# Altium schematic sheet Sensor_Box_PoE.SchDoc
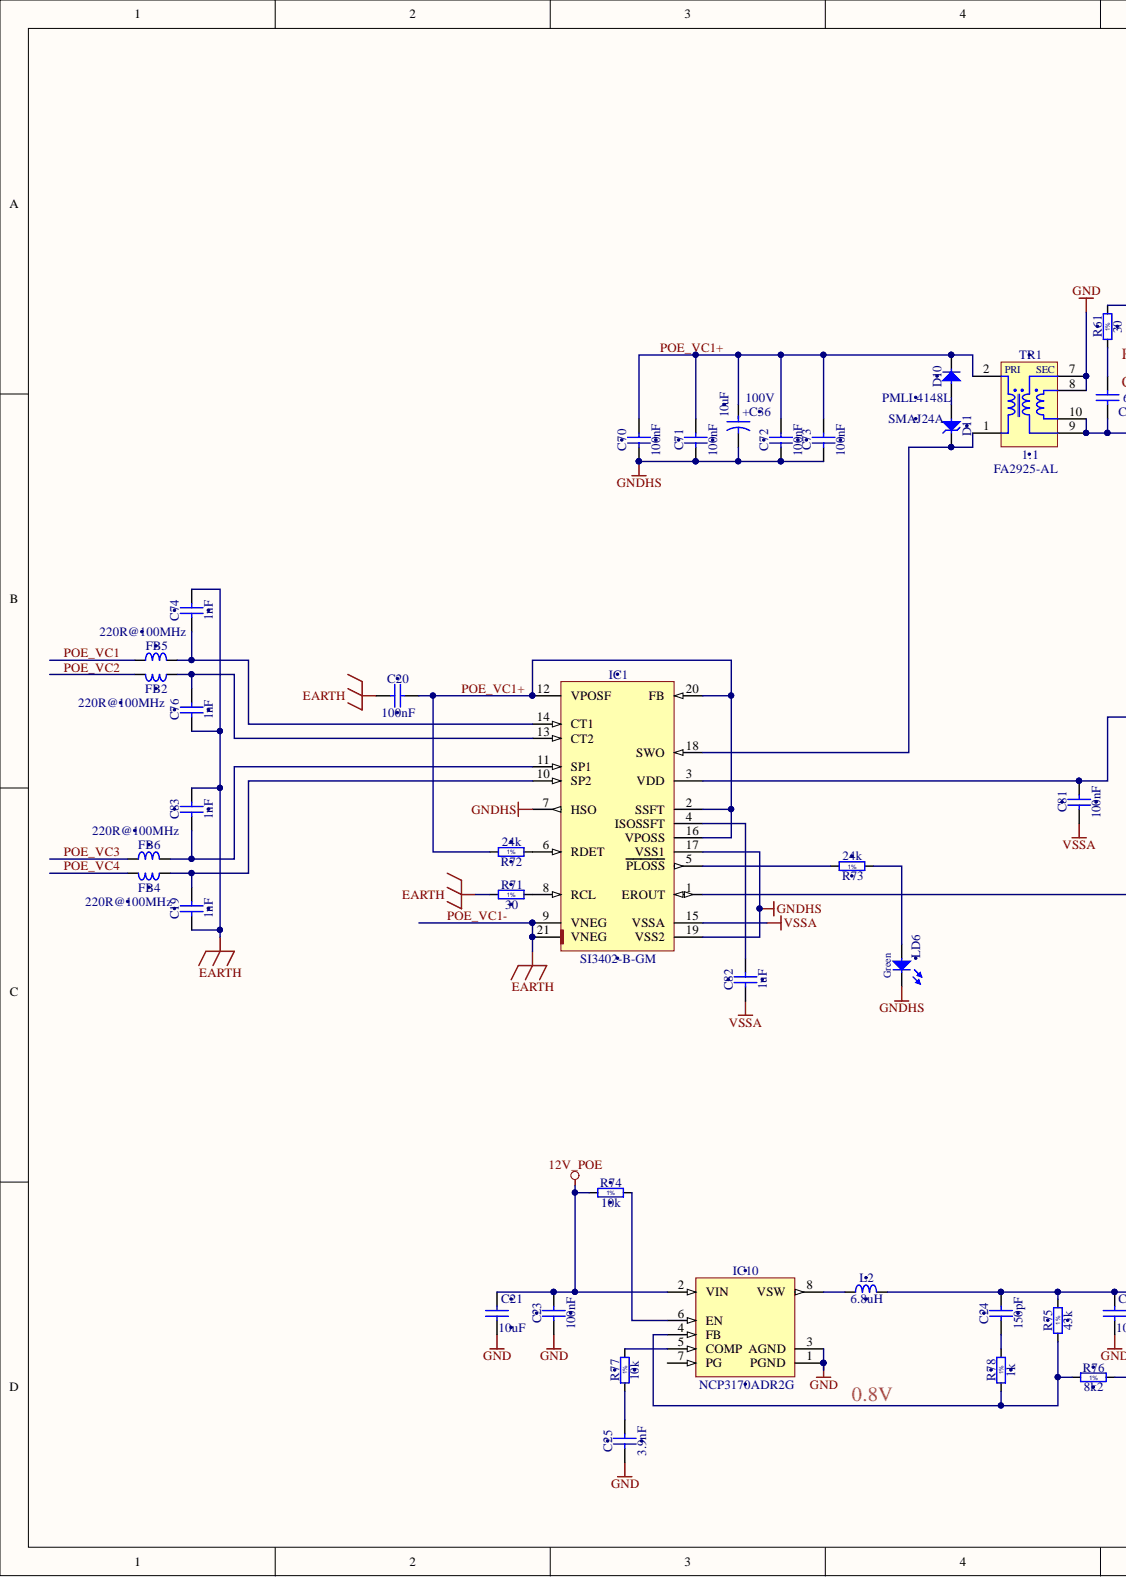
[diagram p0: Sensor_Box_PoE.SchDoc, left]
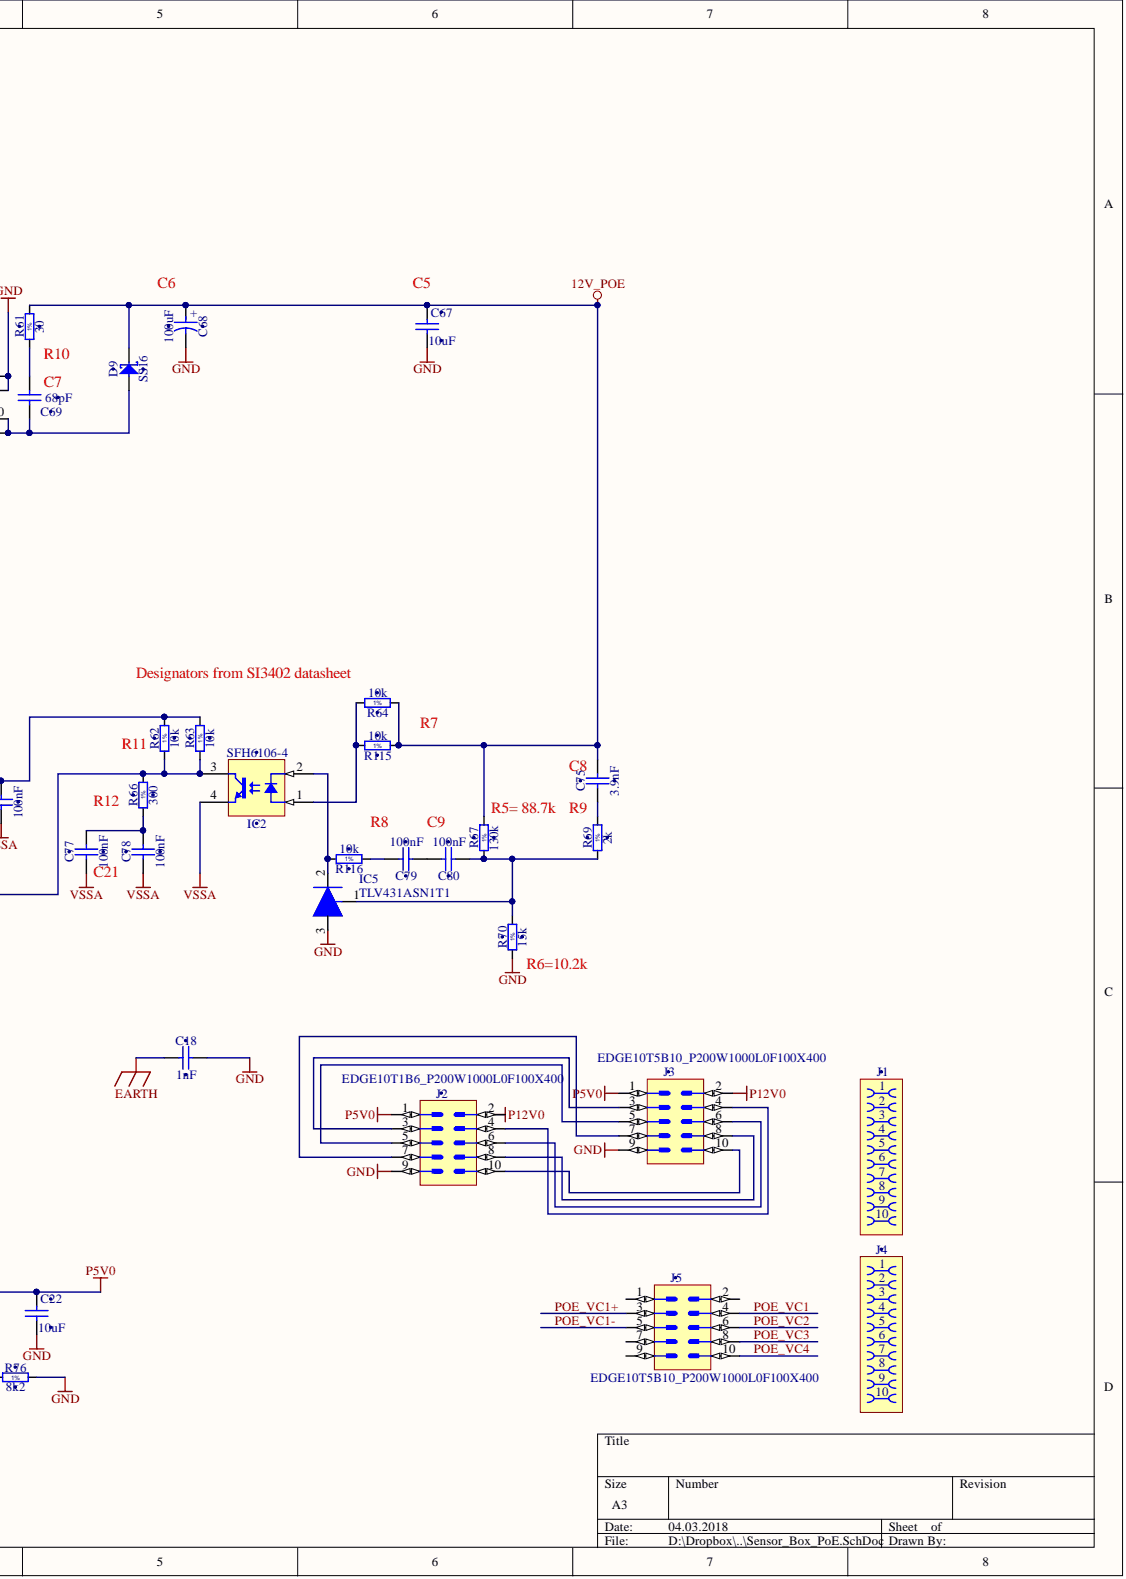
[diagram p1: Sensor_Box_PoE.SchDoc, right]

## Parts
C18  1nF  [CAPC3216X180N]
C19  1nF  [CAPC1608X87N]
C20  100nF  [CAPC3216X140N]
C21  10uF  [CAPC2012X135N]
C22  10uF  [CAPC2012X135N]
C23  100nF  [CAPC1005X55N]
C24  150pF  [CAPC1608X87N]
C25  3.9nF  [CAPC1608X87N]
C36  10uF  Voltage: 100V  [CAPAE830X1020N]
C67  10uF  [CAPC2012X135N]
C68  100uF  [CAPAE660X540N]
C69  68pF  [CAPC1608X87N]
C70  100nF  [CAPC3216X140N]
C71  100nF  [CAPC3216X140N]
C72  100nF  [CAPC3216X140N]
C73  100nF  [CAPC3216X140N]
C74  1nF  [CAPC1608X87N]
C75  3.9nF  [CAPC1608X87N]
C76  1nF  [CAPC1608X87N]
C77  100nF  [CAPC1005X55N]
C78  100nF  [CAPC1005X55N]
C79  100nF  [CAPC1005X55N]
C80  100nF  [CAPC1005X55N]
C81  100nF  [CAPC1005X55N]
C82  1uF  [CAPC1608X87N]
C83  1nF  [CAPC1608X87N]
D9  SS16  [DIOM5127X229N]
D10  PMLL4148L  [DIOMELF3515N]
D11  SMAJ24A  [DIOM5226X262N]
FB2  220R@100MHz  [INDC2012X105N]
FB4  220R@100MHz  [INDC2012X105N]
FB5  220R@100MHz  [INDC2012X105N]
FB6  220R@100MHz  [INDC2012X105N]
IC1  SI3402-B-GM  [QFN80P500X500X80-21N-S280]
IC2  SFH6106-4  [SOIC254P977X406-4N]
IC5  TLV431ASN1T1  [SOT-23]
IC10  NCP3170ADR2G  [SOIC127P600X175-8N]
J1  Family  [AVX_00 9159 010 061 916]  (value not drawn)
J2  Family  [EDGE10T1B6_P200W1000L0F100X400]  (value not drawn)  (no BOM)
J3  Family  [EDGE10T5B10_P200W1000L0F100X400]  (value not drawn)  (no BOM)
J4  Family  [AVX_00 9159 010 061 916]  (value not drawn)
J5  Family  [EDGE10T5B10_P200W1000L0F100X400]  (value not drawn)  (no BOM)
L2  6.8uH  [IND_BOURNS_SRU8043]
LD6  Green  [LED_KINGBRIGHT_KPTR3216]
R61  30  [RESC1608X55N]
R62  10k  [RESC1005X40N]
R63  10k  [RESC1005X40N]
R64  10k  [RESC1005X40N]
R66  300  [RESC1005X40N]
R67  130k  [RESC1608X55N]
R69  2k  [RESC1005X40N]
R70  15k  [RESC1608X55N]
R71  30  [RESC1608X55N]
R72  24k  [RESC1608X55N]
R73  24k  [RESC1608X55N]
R74  10k  [RESC1005X40N]
R75  43k  [RESC1608X55N]
R76  8k2  [RESC1608X55N]
R77  10k  [RESC1005X40N]
R78  1k  [RESC1005X40N]
R115  10k  [RESC1005X40N]
R116  10k  [RESC1005X40N]
TR1  1:1  Manufacturer Part Number: FA2925-AL  [XFMR_COILCRAFT_FA2925-AL]

## Nets
12V_POE: C21.1 C23.2 C67.1 C68.1 C75.2 D9.1 IC10.2(VIN) R61.2 R64.1 R67.2 R74.1 R115.1
GND: C18.2 C21.2 C22.2 C23.1 C25.1 C67.2 C68.2 IC5.3 IC10.1(PGND) IC10.3(AGND) J2.9 J3.9 R70.1 R76.2 TR1.7 TR1.8
GNDHS: C36.2 C70.1 C71.1 C72.1 C73.1 IC1.7(HSO) IC1.17(VSS1) IC1.19(VSS2) LD6.1
P5V0: C22.1 C24.2 J2.1 J3.1 L2.2 R75.2
P12V0: J3.2
VSSA: C77.1 C78.1 C81.1 C82.1 IC1.15(VSSA) IC2.4
POE_VC1: FB5.1 J5.4
POE_VC1+: C20.2 C36.1 C70.2 C71.2 C72.2 C73.2 D10.1 IC1.2(SSFT) IC1.12(VPOSF) IC1.16(VPOSS) IC1.20(FB) J5.3 R72.2 TR1.2
POE_VC1-: C18.1 C19.1 C20.1 C74.2 C76.1 C83.2 IC1.9(VNEG) IC1.21(VNEG) J5.5 R71.1
POE_VC2: FB2.2 J5.6
POE_VC3: FB6.1 J5.8
POE_VC4: FB4.2 J5.10
NetC19_2: C19.2 FB4.1 IC1.10(SP2)
NetC24_1: C24.1 R78.2
NetC25_2: C25.2 R77.1
NetC69_1: C69.1 D9.2 TR1.9 TR1.10
NetC69_2: C69.2 R61.1
NetC74_1: C74.1 FB5.2 IC1.14(CT1)
NetC75_1: C75.1 R69.2
NetC76_2: C76.2 FB2.1 IC1.13(CT2)
NetC77_2: C77.2 C78.2 R66.1
NetC79_1: C79.1 C80.2
NetC79_2: C79.2 R116.1
NetC80_1: C80.1 IC5.1 R67.1 R69.1 R70.2
NetC81_2: C81.2 IC1.3(VDD) R62.2 R63.2
NetC82_2: C82.2 IC1.4(ISOSSFT)
NetC83_1: C83.1 FB6.2 IC1.11(SP1)
NetD10_2: D10.2 D11.2
NetD11_1: D11.1 IC1.18(SWO) TR1.1
NetIC1_1: IC1.1(EROUT) IC2.3 R62.1 R63.1 R66.2
NetIC1_5: IC1.5(PLOSS) R73.2
NetIC1_6: IC1.6(RDET) R72.1
NetIC1_8: IC1.8(RCL) R71.2
NetIC2_1: IC2.1 R64.2 R115.2
NetIC2_2: IC2.2 IC5.2 R116.2
NetIC10_4: IC10.4(FB) R75.1 R76.1 R78.1
NetIC10_5: IC10.5(COMP) R77.2
NetIC10_6: IC10.6(EN) R74.2
NetIC10_8: IC10.8(VSW) L2.1
NetJ2_3: J2.3 J3.3
NetJ2_4: J2.4 J3.4
NetJ2_5: J2.5 J3.5
NetJ2_6: J2.6 J3.6
NetJ2_7: J2.7 J3.7
NetJ2_8: J2.8 J3.8
NetJ2_10: J2.10 J3.10
NetLD6_2: LD6.2 R73.1

Not connected: IC10 7(PG); J2 2; J5 1 2 7 9

## Library data of the parts
C18 = CC1206_1NF_2KV_10%_X7R: Non-polarised capacitor; Manufacturer: GENERIC; Manufacturer1 Part Number: 1206GC102KAT2A; Part Description: High Voltage MLC Chip Capacitor; Database Table Name: Capacitors SMD; TC: X7R; Tolerance: ±10%; Voltage: 2kV; Case: 1206; Component Kind: Standard; Component Type: Standard; CreateDate: 19.11.2012; HelpURL: \\cern.ch\dfs\Applications\Altium\Datasheets\CC1206_X7R_AVX_HV-MLC.pdf; LatestRevisionDate: 17.05.2017; Library Name: Capacitors.DbLib; Manufacturer1 ComponentHeight: 1.8mm; Manufacturer1 Example: AVX; Manufacturer Part Number: CC1206_1NF_2KV_10%_X7R; Mounted: Yes; Part Number: CC1206_1NF_2KV_10%_X7R; Pin Count: 2; Sense: No; SMD: Yes; Socket: No; Status: None; Value: 1nF; Author: CERN DEM JLC
C19 C74 C76 C83 = CC0603_1NF_100V_10%_X7R: Non-polarised capacitor; Manufacturer: GENERIC; Manufacturer1 Part Number: C0603C102K1RACTU; Part Description: SMD Multilayer Chip Ceramic Capacitor; Database Table Name: Capacitors SMD; TC: X7R; Tolerance: ±10%; Voltage: 100V; Case: 0603; Component Kind: Standard; Component Type: Standard; CreateDate: 12.12.2013; HelpURL: \\cern.ch\dfs\Applications\Altium\Datasheets\CC0603_X7R_KEMET.pdf; LatestRevisionDate: 12.12.2013; Library Name: Capacitors.DbLib; Manufacturer1 ComponentHeight: 0.87mm; Manufacturer1 Example: KEMET; Manufacturer Part Number: CC0603_1NF_100V_10%_X7R; Mounted: Yes; Part Number: CC0603_1NF_100V_10%_X7R; Pin Count: 2; Sense: No; SMD: Yes; Socket: No; Status: Preferred; Value: 1nF; Author: CERN DEM JLC
C20 C70-C73 = CC1206_100NF_100V_10%_X7R: Non-polarised capacitor; Manufacturer: GENERIC; Manufacturer1 Part Number: 222260115649; Part Description: SMD Multilayer Chip Ceramic Capacitor; Database Table Name: Capacitors SMD; TC: X7R; Tolerance: ±10%; Voltage: 100V; Case: 1206; Component Kind: Standard; Component Type: Standard; CreateDate: 24.08.2009; HelpURL: \\cern.ch\dfs\Applications\Altium\Datasheets\CC1206_X7R_PHYCOMP.pdf; LatestRevisionDate: 24.08.2009; Library Name: Capacitors.DbLib; Manufacturer1 ComponentHeight: 1.25mm; Manufacturer1 Example: PHYCOMP; Manufacturer Part Number: CC1206_100NF_100V_10%_X7R; Mounted: Yes; Part Number: CC1206_100NF_100V_10%_X7R; Pin Count: 2; Sense: No; SMD: Yes; Socket: No; Status: Preferred; Value: 100nF; Author: CERN DEM JLC
C21 C22 C67 = CC0805_10UF_10V_10%_X7R: Non-polarised capacitor; Manufacturer: GENERIC; Manufacturer1 Part Number: GRM21BR71A106KE51L; Part Description: SMD Multilayer Chip Ceramic Capacitor; Database Table Name: Capacitors SMD; TC: X7R; Tolerance: ±10%; Voltage: 10V; Case: 0805; Component Kind: Standard; Component Type: Standard; CreateDate: 2012-04-30; HelpURL: \\cern.ch\dfs\Applications\Altium\Datasheets\CC0805_X7R_MURATA.pdf; LatestRevisionDate: 2012-04-30; Library Name: Capacitors.DbLib; Manufacturer1 ComponentHeight: 1.35mm; Manufacturer1 Example: MURATA; Manufacturer Part Number: CC0805_10UF_10V_10%_X7R; Mounted: Yes; Part Number: CC0805_10UF_10V_10%_X7R; Pin Count: 2; SMD: Yes; Socket: No; Status: Preferred; Table: Capacitors SMD; Value: 10uF; Author: CERN DEM JLC
C23 C77-C81 = CC0402_100NF_16V_10%_X5R: Non-polarised capacitor; Manufacturer: GENERIC; Manufacturer1 Part Number: GRM155R61C104KA88D; Part Description: SMD Multilayer Chip Ceramic Capacitor; Database Table Name: Capacitors SMD; TC: X5R; Tolerance: ±10%; Voltage: 16V; Case: 0402; Component Kind: Standard; Component Type: Standard; CreateDate: 10.12.2013; HelpURL: \\cern.ch\dfs\Applications\Altium\Datasheets\CC0402_X5R_MURATA_GRM.pdf; LatestRevisionDate: 10.12.2013; Library Name: Capacitors.DbLib; Manufacturer1 ComponentHeight: 0.55mm; Manufacturer1 Example: MURATA; Manufacturer Part Number: CC0402_100NF_16V_10%_X5R; Mounted: Yes; Part Number: CC0402_100NF_16V_10%_X5R; Pin Count: 2; Sense: No; SMD: Yes; Socket: No; Status: None; Value: 100nF; Author: CERN DEM JLC
C24 = CC0603_150PF_50V_1%_NP0: Non-polarised capacitor; Manufacturer: GENERIC; Manufacturer1 Part Number: CC0603FRNPO9BN151; Part Description: SMD Multilayer Chip Ceramic Capacitor; Database Table Name: Capacitors SMD; TC: C0G/NP0; Tolerance: ±1%; Voltage: 50V; Case: 0603; Component Kind: Standard; Component Type: Standard; CreateDate: 17.02.2016; HelpURL: \\cern.ch\dfs\Applications\Altium\Datasheets\CC0805_NP0_PHYCOMP_16VTO50V.pdf; LatestRevisionDate: 17.02.2016; Library Name: Capacitors.DbLib; Manufacturer1 ComponentHeight: 0.7mm; Manufacturer1 Example: PHYCOMP; Manufacturer Part Number: CC0603_150PF_50V_1%_NP0; Mounted: Yes; Part Number: CC0603_150PF_50V_1%_NP0; Pin Count: 2; Sense: No; SMD: Yes; Socket: No; Status: None; Value: 150pF; Author: CERN DEM MR
C25 C75 = CC0603_3.9NF_50V_5%_X7R: Non-polarised capacitor; Manufacturer: GENERIC; Manufacturer1 Part Number: 223858615631; Part Description: SMD Multilayer Chip Ceramic Capacitor; Database Table Name: Capacitors SMD; TC: X7R; Tolerance: ±5%; Voltage: 50V; Case: 0603; Component Kind: Standard; Component Type: Standard; CreateDate: 03.12.2007; HelpURL: \\cern.ch\dfs\Applications\Altium\Datasheets\CC0603_X7R_PHYCOMP.pdf; LatestRevisionDate: 03.12.2007; Library Name: Capacitors.DbLib; Manufacturer1 ComponentHeight: 0.87mm; Manufacturer1 Example: PHYCOMP; Manufacturer Part Number: CC0603_3.9NF_50V_5%_X7R; Mounted: Yes; Part Number: CC0603_3.9NF_50V_5%_X7R; Pin Count: 2; Sense: No; SMD: Yes; Socket: No; Status: Preferred; Value: 3.9nF; Author: CERN DEM JLC
C36 = (no symbol name): 10uF, 100V, 1.5R; Manufacturer: PANASONIC; Manufacturer Part Number: EEEHD2A100P; Part Description: Aluminum Electrolytic SMT Capacitor; Part Number: CAE830-1020_10UF_100V_20%; Table: Capacitors SMD; Tolerance: ±20%; Case: F; ComponentHeight: 10.2mm; Component Kind: Standard; Component Type: Standard; CreateDate: 2007-12-03; HelpURL: \\cern.ch\dfs\Applications\Altium\Datasheets\CAE_PANASONIC_FK.pdf; LatestRevisionDate: 2014-11-05; Library Name: LIBRARY_SCH.SCHLIB; Mounted: Yes; Pin Count: 2; SMD: Yes; Socket: No; Status: Recommended; Value: 10uF; Author: CERN DEM JLC
C68 = CAE660-540_100UF_16V_20%: Polarised capacitor; Manufacturer: PANASONIC; Manufacturer Part Number: EEEFK1C101AP; Part Description: Aluminum Electrolytic SMT Capacitor; Database Table Name: Capacitors SMD; Tolerance: ±20%; Voltage: 16V; Case: D; ComponentHeight: 5.8mm; Component Kind: Standard; Component Type: Standard; CreateDate: 03.12.2007; HelpURL: \\cern.ch\dfs\Applications\Altium\Datasheets\CAE_PANASONIC_FK.pdf; LatestRevisionDate: 05.11.2014; Library Name: Capacitors.DbLib; Mounted: Yes; Part Number: CAE660-540_100UF_16V_20%; Pin Count: 2; Sense: No; SMD: Yes; Socket: No; Status: Not Recommended; Value: 100uF; Author: CERN DEM JLC
C69 = CC0603_68PF_50V_5%_NP0: Non-polarised capacitor; Manufacturer: GENERIC; Manufacturer1 Part Number: 223886715689; Part Description: SMD Multilayer Chip Ceramic Capacitor; Database Table Name: Capacitors SMD; TC: C0G/NP0; Tolerance: ±5%; Voltage: 50V; Case: 0603; Component Kind: Standard; Component Type: Standard; CreateDate: 03.12.2007; HelpURL: \\cern.ch\dfs\Applications\Altium\Datasheets\CC0603_NP0_PHYCOMP.pdf; LatestRevisionDate: 03.12.2007; Library Name: Capacitors.DbLib; Manufacturer1 ComponentHeight: 0.87mm; Manufacturer1 Example: PHYCOMP; Manufacturer Part Number: CC0603_68PF_50V_5%_NP0; Mounted: Yes; Part Number: CC0603_68PF_50V_5%_NP0; Pin Count: 2; Sense: No; SMD: Yes; Socket: No; Status: Preferred; Value: 68pF; Author: CERN DEM JLC
C82 = CC0603_1UF_16V_10%_X7R: Non-polarised capacitor; Manufacturer: GENERIC; Manufacturer1 Part Number: 223878615663; Part Description: SMD Multilayer Chip Ceramic Capacitor; Database Table Name: Capacitors SMD; TC: X7R; Tolerance: ±10%; Voltage: 16V; Case: 0603; Component Kind: Standard; Component Type: Standard; CreateDate: 03.12.2007; HelpURL: \\cern.ch\dfs\Applications\Altium\Datasheets\CC0603_X7R_PHYCOMP.pdf; LatestRevisionDate: 17.04.2015; Library Name: Capacitors.DbLib; Manufacturer1 ComponentHeight: 0.9mm; Manufacturer1 Example: YAGEO PHYCOMP; Manufacturer Part Number: CC0603_1uF_16V_10%_X7R; Mounted: Yes; Part Number: CC0603_1UF_16V_10%_X7R; Pin Count: 2; Sense: No; SMD: Yes; Socket: No; Status: None; Value: 1uF; Author: CERN DEM JLC
D9 = SS16: Schottky Diode; Manufacturer: VISHAY GENERAL SEMICONDUCTOR; Part Description: Surface Mount Schottky Barrier Rectifier; Bonding: No; Database Table Name: Diodes; Power: 1A; PressFit: No; Voltage: 60V; Case: DO-214AC; ComponentHeight: 2.29mm; Component Kind: Standard; Component Type: Standard; CreateDate: 15.07.2008; Device: SS16; HelpURL: \\cern.ch\dfs\Applications\Altium\Datasheets\SS16.pdf; LatestRevisionDate: 23.08.2010; Library Name: ICs And Semiconductors.DbLib; Manufacturer Part Number: SS16; Mounted: Yes; PackageDescription: DO-214-AC Molded Diode, Body 5.1x2.7mm, IPC Medium Density; Part Number: SS16; Pin Count: 2; Sense: No; SMD: Yes; Socket: No; Author: CERN DEM JLC
D10 = PMLL4148L: Diode; Manufacturer: NXP SEMICONDUCTORS; Part Description: High-speed switching diodes; Bonding: No; Database Table Name: Diodes; Power: 200mA; PressFit: No; Voltage: 75V; Case: SOD-80C; ComponentHeight: 1.6mm; Component Kind: Standard; Component Type: Standard; CreateDate: 30.03.2009; Device: PMLL4148L; HelpURL: \\cern.ch\dfs\Applications\Altium\Datasheets\PMLL4148L.pdf; LatestRevisionDate: 09.12.2009; Library Name: ICs And Semiconductors.DbLib; Manufacturer Part Number: PMLL4148L; Mounted: Yes; PackageDescription: SOD80C, MELF Diode, 2-Leads, Body 3.5x1.5mm, IPC Medium Density; Part Number: PMLL4148L; Pin Count: 2; Sense: No; SMD: Yes; Socket: No; Status: Not recommended; Author: CERN DEM JLC
D11 = SMAJ24A: Transient Voltage Suppressor Diode (Uni-Directional); Manufacturer: BOURNS; Part Description: Surface Mount Uni-directional Transient Voltage Suppressor Diode; Bonding: No; Database Table Name: Diodes; Power: 400W; PressFit: No; Voltage: 24V; Case: DO-214AC; ComponentHeight: 2.62mm; Component Kind: Standard; Component Type: Standard; CreateDate: 27.06.2011; Device: SMAJ24A; HelpURL: \\cern.ch\dfs\Applications\Altium\Datasheets\SMAJ24A.pdf; LatestRevisionDate: 27.06.2011; Library Name: ICs And Semiconductors.DbLib; Manufacturer Part Number: SMAJ24A; Mounted: Yes; PackageDescription: DO-214-AC/SMA Diode, Molded Body; 5.21mm L X 2.605mm W X 2.62mm H, IPC Medium Density; Part Number: SMAJ24A; Pin Count: 2; Sense: No; SMD: Yes; Socket: No; Author: CERN DEM JLC
FB2 FB4-FB6 = IND0805_MURATA_BLM21PG221SN1D: Inductor; Manufacturer: MURATA; Part Description: SMD EMIFIL Suppression Chip Ferrite Bead; Database Table Name: Inductors SMD; Power: 2A; Resistance: 0.05R; Tolerance: ±25%; Case: 0805; ComponentHeight: 1.05mm; Component Kind: Standard; Component Type: Standard; CreateDate: 2010-01-25; HelpURL: \\cern.ch\dfs\Applications\Altium\Datasheets\IND0402_MURATA_BLM21P.pdf; LatestRevisionDate: 2010-01-25; Library Name: Inductors & Transformers.DbLib; Manufacturer Part Number: BLM21PG221SN1D; Mounted: Yes; Part Number: IND0805_MURATA_BLM21PG221SN1D; Pin Count: 2; SMD: Yes; Socket: No; Status: None; Table: Inductors SMD; Value: 220R@100MHz; Author: CERN DEM JLC
IC1 = SI3402-B-GM: Fully Integrated IEEE 802.3 Compliant PoE PD Interface and Low-EMI Switching Regulator; Manufacturer: SILICON LABS; Bonding: No; Database Table Name: Regulators; PressFit: No; Case: QFN20; ComponentHeight: 0.8mm; Component Kind: Standard; Component Type: Standard; CreateDate: 21.02.2018; Device: SI3402-B-GM; HelpURL: \\cern.ch\dfs\Applications\Altium\Datasheets\SI3402-B-GM.pdf; LatestRevisionDate: 21.02.2018; Library Name: ICs And Semiconductors.DbLib; Manufacturer Part Number: SI3402-B-GM; Mounted: Yes; PackageDescription: QFN, 0.80mm Pitch, Square 20 Pin, 5.00mm L X 5.00mm W X 0.80mm H body (W/Thermal Tab 2.8mm X 2.8mm), IPC Medium Density; Part Description: Fully Integrated IEEE 802.3 Compliant PoE PD Interface and Low-EMI Switching Regulator; Part Number: SI3402-B-GM; Pin Count: 21; Sense: No; SMD: Yes; Socket: No; Author: CERN DEM MR
IC2 = SFH6106-4: Single Optocoupler; Manufacturer: VISHAY SEMICONDUCTORS; Part Description: Optocoupler, Phototransistor Output, High Reliability, 5300 VRMS; Bonding: No; Database Table Name: Optocouplers; PressFit: No; Case: SOIC4; ComponentHeight: 4.06mm; Component Kind: Standard; Component Type: Standard; CreateDate: 15.02.2018; Device: SFH6106-4; HelpURL: \\cern.ch\dfs\Applications\Altium\Datasheets\SFH6106-4.pdf; LatestRevisionDate: 15.02.2018; Library Name: ICs And Semiconductors.DbLib; Manufacturer Part Number: SFH6106-4; Mounted: Yes; PackageDescription: SOIC, 2.54mm pitch; 4 pin, 6.65mm W X 4.69mm L, IPC Medium Density; Part Number: SFH6106-4; Pin Count: 4; Sense: No; SMD: Yes; Socket: No; Author: CERN DEM MR
IC5 = REF_TLV431_SOT23_16V: 16V 1.24V ADJ Voltage reference; Component Kind: Standard; Library Name: LIBRARY_SCH.SCHLIB; Supplier 1: Newark; Supplier Part Number 1: 31K7719
IC10 = NCP3170ADR2G: Synchronous PWM Switching Converter; Manufacturer: ON SEMICONDUCTOR; Bonding: No; Database Table Name: Analog & Interface; PressFit: No; Case: SOIC8; ComponentHeight: 1.75mm; Component Kind: Standard; Component Type: Standard; CreateDate: 30.05.2016; Device: NCP3170ADR2G; HelpURL: \\cern.ch\dfs\Applications\Altium\Datasheets\NCP3170ADR2G.pdf; LatestRevisionDate: 30.05.2016; Library Name: ICs And Semiconductors.DbLib; Manufacturer Part Number: NCP3170ADR2G; Mounted: Yes; PackageDescription: SOIC 8, Pitch 1.27mm - Body 4x5mm, IPC Medium Density; Part Description: Synchronous PWM Switching Converter; Part Number: NCP3170ADR2G; Pin Count: 8; Sense: No; SMD: Yes; Socket: No; Author: CERN DEM MR
J1 J4 = AVX_00 9159 010 061 916: Open Ended Card Edge Connector, 9159 Series; Manufacturer: AVX; Part Description: 10 Contacts, 2mm Pitch, Open Ended Card Edge Connector, 9159 Series; Database Table Name: Miscellaneous; PressFit: No; ComponentHeight: 1.6mm; Component Kind: Standard; Component Type: Standard; CreateDate: 13.02.2018; HelpURL: \\cern.ch\dfs\Applications\Altium\Datasheets\AVX_00 9159 010 061 916.pdf; LatestRevisionDate: 13.02.2018; Library Name: Connectors.DbLib; Manufacturer Part Number: 00 9159 010 061 916; Mounted: Yes; Part Number: AVX_00 9159 010 061 916; Pin Count: 10; Sense: No; SMD: No; Socket: No; Status: None; Author: CERN DEM MR
J2 = EDGE10T1B6_P200W1000L0F100X400: PCB Edge Connector 10 (2x5); Part Description: 10 Contacts Board Edge Connector, Pitch 2mm, Length 0mm, Width 10mm, Finger Lenght 4mm, Finger Width 1mm; Database Table Name: Miscellaneous; Mounted: No; PressFit: No; ComponentHeight: 0mm; Component Type: Standard (No BOM); CreateDate: 14.02.2018; HelpURL: \\cern.ch\dfs\Applications\Altium\Datasheets\EDGE10T1B6_P200W1000L0F100X400.pdf; LatestRevisionDate: 14.02.2018; Library Name: Connectors.DbLib; Part Number: EDGE10T1B6_P200W1000L0F100X400; Pin Count: 10; Sense: No; SMD: No; Socket: No; Status: None; Author: CERN DEM MR
J3 J5 = EDGE10T5B10_P200W1000L0F100X400: PCB Edge Connector 10 (2x5); Part Description: 10 Contacts Board Edge Connector, Pitch 2mm, Length 0mm, Width 10mm, Finger Lenght 4mm, Finger Width 1mm; Database Table Name: Miscellaneous; Mounted: No; PressFit: No; ComponentHeight: 0mm; Component Type: Standard (No BOM); CreateDate: 14.02.2018; HelpURL: \\cern.ch\dfs\Applications\Altium\Datasheets\EDGE10T5B10_P200W1000L0F100X400.pdf; LatestRevisionDate: 14.02.2018; Library Name: Connectors.DbLib; Part Number: EDGE10T5B10_P200W1000L0F100X400; Pin Count: 10; Sense: No; SMD: No; Socket: No; Status: None; Author: CERN DEM MR
L2 = IND_6.8UH_30%_BOURNS_SRU8043: Inductor; Manufacturer: BOURNS; Manufacturer Part Number: SRU8043-6R8Y; Part Description: Shielded SMD Power Inductor; Database Table Name: Inductors SMD; Power: 3.8A; Resistance: 0.0224R; Tolerance: ±30%; ComponentHeight: 4.6mm; Component Kind: Standard; Component Type: Standard; CreateDate: 06.08.2015; HelpURL: \\cern.ch\dfs\Applications\Altium\Datasheets\IND_BOURNS_SRU8043.pdf; LatestRevisionDate: 06.08.2015; Library Name: Inductors & Transformers.DbLib; Mounted: Yes; Part Number: IND_6.8UH_30%_BOURNS_SRU8043; Pin Count: 2; Sense: No; SMD: Yes; Socket: No; Status: None; Value: 6.8uH; Author: CERN DEM JMW
LD6 = LED_KINGBRIGHT_KPTR-3216CGCK: LED Green 1A 2C; Part Description: Reverse Mount LED 1.4x1.6mm Green; Bonding: No; Database Table Name: LEDs & Displays; PressFit: No; Color: Green; ComponentHeight: 0.35mm; Component Kind: Standard; Component Type: Standard; CreateDate: 15.02.2016; HelpURL: \\cern.ch\dfs\Applications\Altium\Datasheets\LED_KINGBRIGHT_KPTR-3216CGCK.pdf; LatestRevisionDate: 15.02.2016; Library Name: ICs And Semiconductors.DbLib; Manufacturer: KINGBRIGHT; Manufacturer Part Number: KPTR-3216CGCK; Mounted: Yes; PackageDescription: Reverse Mount LED 3216, Hole 2.1x2.3mm 0.35mm Height; Part Number: LED_KINGBRIGHT_KPTR-3216CGCK; Pin Count: 2; Sense: No; SMD: Yes; Socket: No; Author: CERN DEM MR
R61 R71 = R0603_30R_1%_0.1W_100PPM: Resistor - 1%; Manufacturer: GENERIC; Manufacturer1 Part Number: NRC06F30R0TRF; Part Description: General Purpose Thick Film Chip Resistor; Database Table Name: Resistors SMD; Power: 0.1W; PressFit: No; TC: ±100ppm/°C; Case: 0603; Component Kind: Standard; Component Type: Standard; CreateDate: 03.12.2007; HelpURL: \\cern.ch\dfs\Applications\Altium\Datasheets\R0603_NIC_NRC.pdf; LatestRevisionDate: 13.03.2008; Library Name: Resistors.DbLib; Manufacturer1 ComponentHeight: 0.55mm; Manufacturer1 Example: NIC COMPONENT; Manufacturer Part Number: R0603_30R_1%_0.1W_100PPM; Mounted: Yes; Part Number: R0603_30R_1%_0.1W_100PPM; Pin Count: 2; Sense: No; SMD: Yes; Socket: No; Status: Preferred; Tolerance: ±1%; Value: 30; Author: CERN DEM JLC
R62-R64 R74 R77 R115 R116 = R0402_10K_1%_0.0625W_100PPM: Resistor - 1%; Manufacturer: GENERIC; Manufacturer1 Part Number: 232270671003L; Part Description: General Purpose Thick Film Chip Resistor; Database Table Name: Resistors SMD; PressFit: No; TC: ±100ppm/°C; Case: 0402; Component Kind: Standard; Component Type: Standard; CreateDate: 03.12.2007; HelpURL: \\cern.ch\dfs\Applications\Altium\Datasheets\R0402_Phycomp_RC0402.pdf; LatestRevisionDate: 17.10.2012; Library Name: Resistors.DbLib; Manufacturer1 ComponentHeight: 0.4mm; Manufacturer1 Example: YAGEO PHYCOMP; Manufacturer Part Number: R0402_10K_1%_0.0625W_100PPM; Mounted: Yes; Part Number: R0402_10K_1%_0.0625W_100PPM; Pin Count: 2; Power: 0.0625W; Sense: No; SMD: Yes; Socket: No; Status: Not Recommended; Tolerance: ±1%; Value: 10k; Author: CERN DEM JLC
R66 = R0402_300R_1%_0.0625W_100PPM: Resistor - 1%; Manufacturer: GENERIC; Manufacturer1 Part Number: 232270673001L; Part Description: General Purpose Thick Film Chip Resistor; Database Table Name: Resistors SMD; PressFit: No; TC: ±100ppm/°C; Case: 0402; Component Kind: Standard; Component Type: Standard; CreateDate: 03.12.2007; HelpURL: \\cern.ch\dfs\Applications\Altium\Datasheets\R0402_Phycomp_RC0402.pdf; LatestRevisionDate: 17.10.2012; Library Name: Resistors.DbLib; Manufacturer1 ComponentHeight: 0.4mm; Manufacturer1 Example: YAGEO PHYCOMP; Manufacturer Part Number: R0402_300R_1%_0.0625W_100PPM; Mounted: Yes; Part Number: R0402_300R_1%_0.0625W_100PPM; Pin Count: 2; Power: 0.0625W; Sense: No; SMD: Yes; Socket: No; Status: Not Recommended; Tolerance: ±1%; Value: 300; Author: CERN DEM JLC
R67 = R0603_130K_1%_0.1W_100PPM: Resistor - 1%; Manufacturer: GENERIC; Manufacturer1 Part Number: NRC06F1303TRF; Part Description: General Purpose Thick Film Chip Resistor; Database Table Name: Resistors SMD; Power: 0.1W; PressFit: No; TC: ±100ppm/°C; Case: 0603; Component Kind: Standard; Component Type: Standard; CreateDate: 03.12.2007; HelpURL: \\cern.ch\dfs\Applications\Altium\Datasheets\R0603_NIC_NRC.pdf; LatestRevisionDate: 13.03.2008; Library Name: Resistors.DbLib; Manufacturer1 ComponentHeight: 0.55mm; Manufacturer1 Example: NIC COMPONENT; Manufacturer Part Number: R0603_130K_1%_0.1W_100PPM; Mounted: Yes; Part Number: R0603_130K_1%_0.1W_100PPM; Pin Count: 2; Sense: No; SMD: Yes; Socket: No; Status: Preferred; Tolerance: ±1%; Value: 130k; Author: CERN DEM JLC
R69 = R0402_2K_1%_0.0625W_100PPM: Resistor - 1%; Manufacturer: GENERIC; Manufacturer1 Part Number: 232270672002L; Part Description: General Purpose Thick Film Chip Resistor; Database Table Name: Resistors SMD; PressFit: No; TC: ±100ppm/°C; Case: 0402; Component Kind: Standard; Component Type: Standard; CreateDate: 03.12.2007; HelpURL: \\cern.ch\dfs\Applications\Altium\Datasheets\R0402_Phycomp_RC0402.pdf; LatestRevisionDate: 17.10.2012; Library Name: Resistors.DbLib; Manufacturer1 ComponentHeight: 0.4mm; Manufacturer1 Example: YAGEO PHYCOMP; Manufacturer Part Number: R0402_2K_1%_0.0625W_100PPM; Mounted: Yes; Part Number: R0402_2K_1%_0.0625W_100PPM; Pin Count: 2; Power: 0.0625W; Sense: No; SMD: Yes; Socket: No; Status: Not Recommended; Tolerance: ±1%; Value: 2k; Author: CERN DEM JLC
R70 = R0603_15K_1%_0.1W_100PPM: Resistor - 1%; Manufacturer: GENERIC; Manufacturer1 Part Number: NRC06F1502TRF; Part Description: General Purpose Thick Film Chip Resistor; Database Table Name: Resistors SMD; Power: 0.1W; PressFit: No; TC: ±100ppm/°C; Case: 0603; Component Kind: Standard; Component Type: Standard; CreateDate: 17.08.2015; HelpURL: \\cern.ch\dfs\Applications\Altium\Datasheets\R0603_NIC_NRC.pdf; LatestRevisionDate: 17.08.2015; Library Name: Resistors.DbLib; Manufacturer1 ComponentHeight: 0.55mm; Manufacturer1 Example: NIC COMPONENT; Manufacturer Part Number: R0603_15K_1%_0.1W_100PPM; Mounted: Yes; Part Number: R0603_15K_1%_0.1W_100PPM; Pin Count: 2; Sense: No; SMD: Yes; Socket: No; Status: Preferred; Tolerance: ±1%; Value: 15k; Author: CERN DEM JMW
R72 R73 = R0603_24K_1%_0.1W_100PPM: Resistor - 1%; Manufacturer: GENERIC; Manufacturer1 Part Number: NRC06F2402TRF; Part Description: General Purpose Thick Film Chip Resistor; Database Table Name: Resistors SMD; Power: 0.1W; PressFit: No; TC: ±100ppm/°C; Case: 0603; Component Kind: Standard; Component Type: Standard; CreateDate: 03.12.2007; HelpURL: \\cern.ch\dfs\Applications\Altium\Datasheets\R0603_NIC_NRC.pdf; LatestRevisionDate: 13.03.2008; Library Name: Resistors.DbLib; Manufacturer1 ComponentHeight: 0.55mm; Manufacturer1 Example: NIC COMPONENT; Manufacturer Part Number: R0603_24K_1%_0.1W_100PPM; Mounted: Yes; Part Number: R0603_24K_1%_0.1W_100PPM; Pin Count: 2; Sense: No; SMD: Yes; Socket: No; Status: Preferred; Tolerance: ±1%; Value: 24k; Author: CERN DEM JLC
R75 = R0603_43K_1%_0.1W_100PPM: Resistor - 1%; Manufacturer: GENERIC; Manufacturer1 Part Number: NRC06F4302TRF; Part Description: General Purpose Thick Film Chip Resistor; Database Table Name: Resistors SMD; Power: 0.1W; PressFit: No; TC: ±100ppm/°C; Case: 0603; Component Kind: Standard; Component Type: Standard; CreateDate: 03.12.2007; HelpURL: \\cern.ch\dfs\Applications\Altium\Datasheets\R0603_NIC_NRC.pdf; LatestRevisionDate: 13.03.2008; Library Name: Resistors.DbLib; Manufacturer1 ComponentHeight: 0.55mm; Manufacturer1 Example: NIC COMPONENT; Manufacturer Part Number: R0603_43K_1%_0.1W_100PPM; Mounted: Yes; Part Number: R0603_43K_1%_0.1W_100PPM; Pin Count: 2; Sense: No; SMD: Yes; Socket: No; Status: Preferred; Tolerance: ±1%; Value: 43k; Author: CERN DEM JLC
R76 = R0603_8K2_1%_0.1W_100PPM: Resistor - 1%; Manufacturer: GENERIC; Manufacturer1 Part Number: NRC06F8201TRF; Part Description: General Purpose Thick Film Chip Resistor; Database Table Name: Resistors SMD; Power: 0.1W; PressFit: No; TC: ±100ppm/°C; Case: 0603; Component Kind: Standard; Component Type: Standard; CreateDate: 03.12.2007; HelpURL: \\cern.ch\dfs\Applications\Altium\Datasheets\R0603_NIC_NRC.pdf; LatestRevisionDate: 13.03.2008; Library Name: Resistors.DbLib; Manufacturer1 ComponentHeight: 0.55mm; Manufacturer1 Example: NIC COMPONENT; Manufacturer Part Number: R0603_8K2_1%_0.1W_100PPM; Mounted: Yes; Part Number: R0603_8K2_1%_0.1W_100PPM; Pin Count: 2; Sense: No; SMD: Yes; Socket: No; Status: Preferred; Tolerance: ±1%; Value: 8k2; Author: CERN DEM JLC
R78 = R0402_1K_1%_0.0625W_100PPM: Resistor - 1%; Manufacturer: GENERIC; Manufacturer1 Part Number: 232270671002L; Part Description: General Purpose Thick Film Chip Resistor; Database Table Name: Resistors SMD; PressFit: No; TC: ±100ppm/°C; Case: 0402; Component Kind: Standard; Component Type: Standard; CreateDate: 03.12.2007; HelpURL: \\cern.ch\dfs\Applications\Altium\Datasheets\R0402_Phycomp_RC0402.pdf; LatestRevisionDate: 17.10.2012; Library Name: Resistors.DbLib; Manufacturer1 ComponentHeight: 0.4mm; Manufacturer1 Example: YAGEO PHYCOMP; Manufacturer Part Number: R0402_1K_1%_0.0625W_100PPM; Mounted: Yes; Part Number: R0402_1K_1%_0.0625W_100PPM; Pin Count: 2; Power: 0.0625W; Sense: No; SMD: Yes; Socket: No; Status: Not Recommended; Tolerance: ±1%; Value: 1k; Author: CERN DEM JLC
TR1 = XFMR_COILCRAFT_FA2925-AL: Flyback Transformer; Part Description: Flyback Transformer For Silicon Laboratories Si3402 PD Controller; Database Table Name: Transformers; ComponentHeight: 12.7mm; Component Kind: Standard; Component Type: Standard; CreateDate: 14.02.2018; HelpURL: \\cern.ch\dfs\Applications\Altium\Datasheets\XFMR_COILCRAFT_FA2925-AL.pdf; LatestRevisionDate: 14.02.2018; Library Name: Inductors & Transformers.DbLib; Manufacturer: COILCRAFT; Mounted: Yes; Part Number: XFMR_COILCRAFT_FA2925-AL; Pin Count: 10; Sense: No; SMD: Yes; Socket: No; Status: None; Value: 1:1; Author: CERN DEM MR
